# Altium schematic sheet 16_Segment_9_Digit_Display_Controller_1.SchDoc
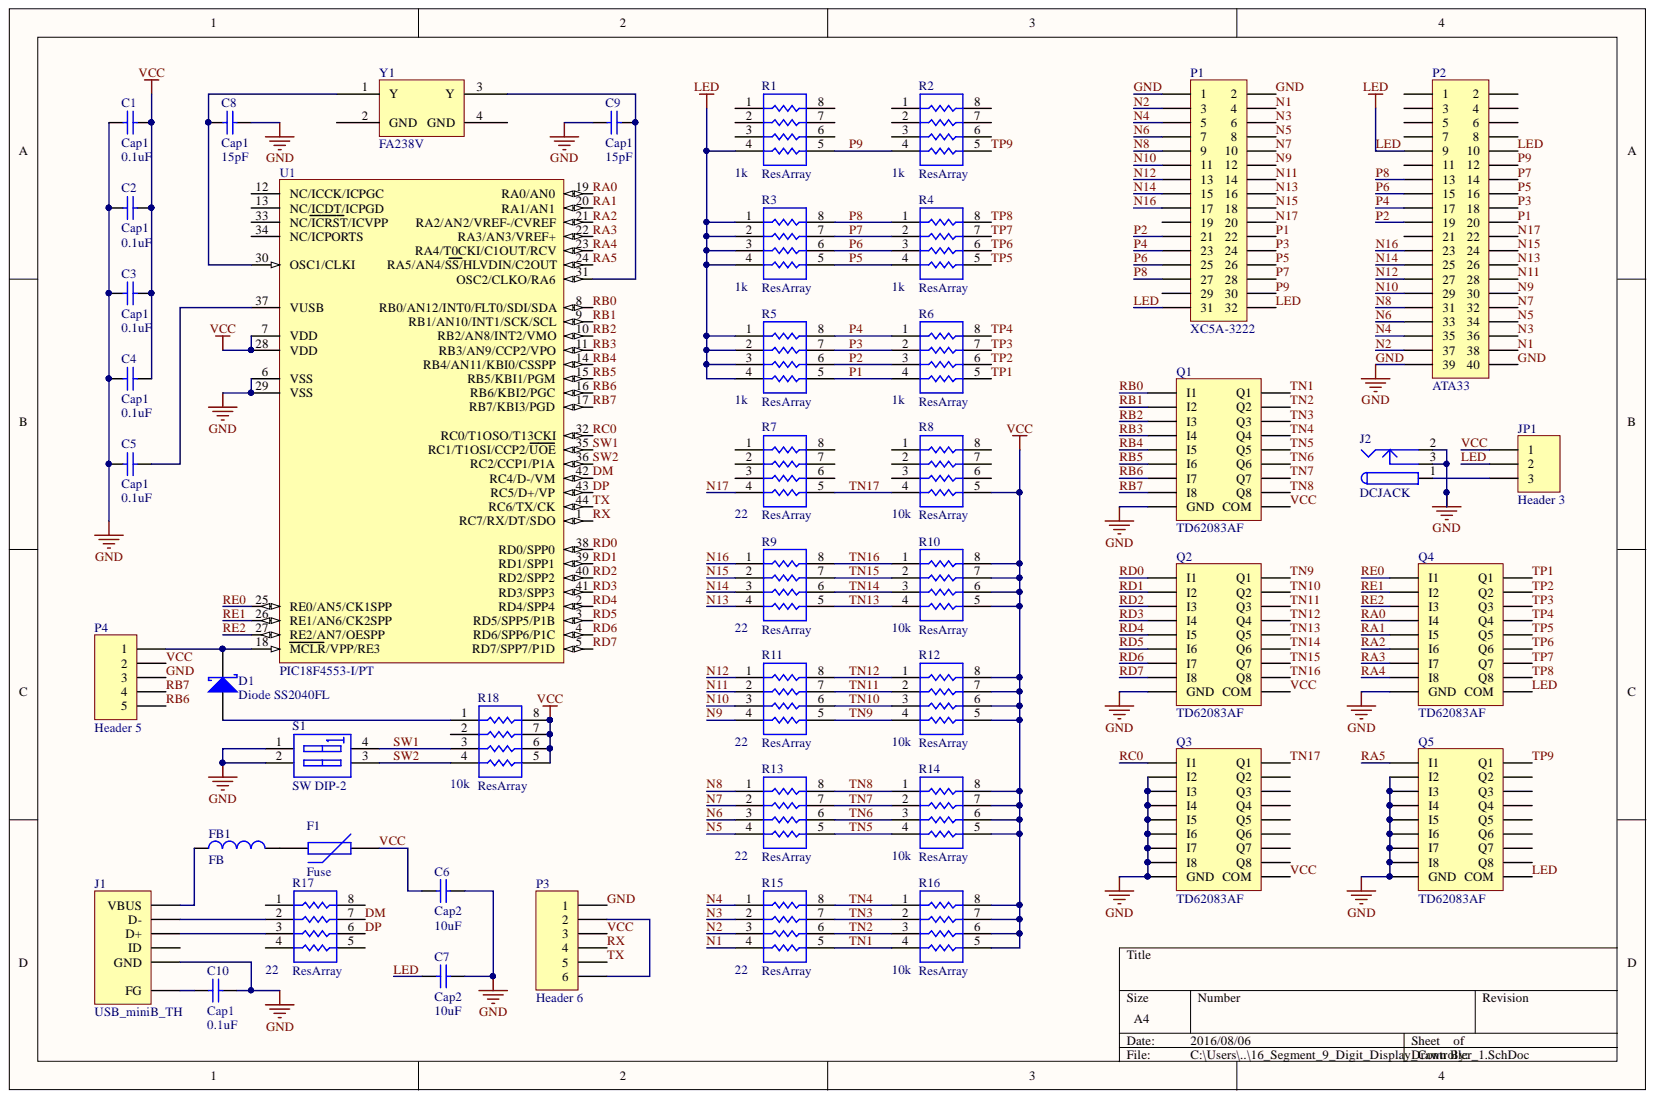
[diagram p0: 16_Segment_9_Digit_Display_Controller_1.SchDoc]

## Parts
C1  Cap1  value 0.1uF  [1608[0603]]
C2  Cap1  value 0.1uF  [1608[0603]]
C3  Cap1  value 0.1uF  [1608[0603]]
C4  Cap1  value 0.1uF  [1608[0603]]
C5  Cap1  value 0.1uF  [1608[0603]]
C6  Cap2  value 10uF  [3216]
C7  Cap2  value 10uF  [3216]
C8  Cap1  value 15pF  [1608[0603]]
C9  Cap1  value 15pF  [1608[0603]]
C10  Cap1  value 0.1uF  [1608[0603]]
D1  Diode SS2040FL  [DIODE_SMC]
F1  Fuse  [FUSE]
FB1  FB  [FB]
J1  USB_miniB_TH  [USB_miniB_TH]
J2  DCJACK  [DCJACK]
JP1  Header 3  [HDR1X3]
P1  XC5A-3222  [XC5A-3222]
P2  ATA33  [ATA33]
P3  Header 6  [HDR1X6]
P4  Header 5  [HDR1X5]
Q1  TD62083AF  [TD62083AF]
Q2  TD62083AF  [TD62083AF]
Q3  TD62083AF  [TD62083AF]
Q4  TD62083AF  [TD62083AF]
Q5  TD62083AF  [TD62083AF]
R1  ResArray  value 1k  [SO8_M]
R2  ResArray  value 1k  [SO8_M]
R3  ResArray  value 1k  [SO8_M]
R4  ResArray  value 1k  [SO8_M]
R5  ResArray  value 1k  [SO8_M]
R6  ResArray  value 1k  [SO8_M]
R7  ResArray  value 22  [SO8_M]
R8  ResArray  value 10k  [SO8_M]
R9  ResArray  value 22  [SO8_M]
R10  ResArray  value 10k  [SO8_M]
R11  ResArray  value 22  [SO8_M]
R12  ResArray  value 10k  [SO8_M]
R13  ResArray  value 22  [SO8_M]
R14  ResArray  value 10k  [SO8_M]
R15  ResArray  value 22  [SO8_M]
R16  ResArray  value 10k  [SO8_M]
R17  ResArray  value 22  [SO8_M]
R18  ResArray  value 10k  [SO8_M]
S1  SW DIP-2  [SOP4-SW]
U1  PIC18F4553-I/PT  [TQFP-PT44_M]
Y1  FA238V  [FA238V]

## Nets
DM: R17.7 U1.42(RC4/D-/VM)
DP: R17.6 U1.43(RC5/D+/VP)
GND: C1.1 C2.1 C3.1 C4.1 C5.1 C6.2 C7.2 C8.2 C9.1 C10.2 J1.5(GND) J2.2 J2.3 P1.1 P1.2 P2.39 P2.40 P3.1 P4.3 Q1.9(GND) Q2.9(GND) Q3.2(I2) Q3.3(I3) Q3.4(I4) Q3.5(I5) Q3.6(I6) Q3.7(I7) Q3.8(I8) Q3.9(GND) Q4.9(GND) Q5.2(I2) Q5.3(I3) Q5.4(I4) Q5.5(I5) Q5.6(I6) Q5.7(I7) Q5.8(I8) Q5.9(GND) S1.1 S1.2 U1.6(VSS) U1.29(VSS)
LED: C7.1 JP1.2 P1.31 P1.32 P2.9 P2.10 Q4.10(COM) Q5.10(COM) R1.4 R3.1 R3.2 R3.3 R3.4 R5.1 R5.2 R5.3 R5.4
N1: P1.4 P2.38 R15.4
N2: P1.3 P2.37 R15.3
N3: P1.6 P2.36 R15.2
N4: P1.5 P2.35 R15.1
N5: P1.8 P2.34 R13.4
N6: P1.7 P2.33 R13.3
N7: P1.10 P2.32 R13.2
N8: P1.9 P2.31 R13.1
N9: P1.12 P2.30 R11.4
N10: P1.11 P2.29 R11.3
N11: P1.14 P2.28 R11.2
N12: P1.13 P2.27 R11.1
N13: P1.16 P2.26 R9.4
N14: P1.15 P2.25 R9.3
N15: P1.18 P2.24 R9.2
N16: P1.17 P2.23 R9.1
N17: P1.20 P2.22 R7.4
P1: P1.22 P2.20 R5.5 R6.4
P2: P1.21 P2.19 R5.6 R6.3
P3: P1.24 P2.18 R5.7 R6.2
P4: P1.23 P2.17 R5.8 R6.1
P5: P1.26 P2.16 R3.5 R4.4
P6: P1.25 P2.15 R3.6 R4.3
P7: P1.28 P2.14 R3.7 R4.2
P8: P1.27 P2.13 R3.8 R4.1
P9: P1.30 P2.12 R1.5 R2.4
RA0: Q4.4(I4) U1.19(RA0/AN0)
RA1: Q4.5(I5) U1.20(RA1/AN1)
RA2: Q4.6(I6) U1.21(RA2/AN2/VREF-/CVREF)
RA3: Q4.7(I7) U1.22(RA3/AN3/VREF+)
RA4: Q4.8(I8) U1.23(RA4/T0CKI/C1OUT/RCV)
RA5: Q5.1(I1) U1.24(RA5/AN4/SS/HLVDIN/C2OUT)
RB0: Q1.1(I1) U1.8(RB0/AN12/INT0/FLT0/SDI/SDA)
RB1: Q1.2(I2) U1.9(RB1/AN10/INT1/SCK/SCL)
RB2: Q1.3(I3) U1.10(RB2/AN8/INT2/VMO)
RB3: Q1.4(I4) U1.11(RB3/AN9/CCP2/VPO)
RB4: Q1.5(I5) U1.14(RB4/AN11/KBI0/CSSPP)
RB5: Q1.6(I6) U1.15(RB5/KBI1/PGM)
RB6: P4.5 Q1.7(I7) U1.16(RB6/KBI2/PGC)
RB7: P4.4 Q1.8(I8) U1.17(RB7/KBI3/PGD)
RC0: Q3.1(I1) U1.32(RC0/T1OSO/T13CKI)
RD0: Q2.1(I1) U1.38(RD0/SPP0)
RD1: Q2.2(I2) U1.39(RD1/SPP1)
RD2: Q2.3(I3) U1.40(RD2/SPP2)
RD3: Q2.4(I4) U1.41(RD3/SPP3)
RD4: Q2.5(I5) U1.2(RD4/SPP4)
RD5: Q2.6(I6) U1.3(RD5/SPP5/P1B)
RD6: Q2.7(I7) U1.4(RD6/SPP6/P1C)
RD7: Q2.8(I8) U1.5(RD7/SPP7/P1D)
RE0: Q4.1(I1) U1.25(RE0/AN5/CK1SPP)
RE1: Q4.2(I2) U1.26(RE1/AN6/CK2SPP)
RE2: Q4.3(I3) U1.27(RE2/AN7/OESPP)
RX: P3.4 U1.1(RC7/RX/DT/SDO)
SW1: R18.3 S1.4 U1.35(RC1/T1OSI/CCP2/UOE)
SW2: R18.4 S1.3 U1.36(RC2/CCP1/P1A)
TN1: Q1.18 R15.5 R16.4
TN2: Q1.17(Q2) R15.6 R16.3
TN3: Q1.16(Q3) R15.7 R16.2
TN4: Q1.15(Q4) R15.8 R16.1
TN5: Q1.14(Q5) R13.5 R14.4
TN6: Q1.13(Q6) R13.6 R14.3
TN7: Q1.12(Q7) R13.7 R14.2
TN8: Q1.11(Q8) R13.8 R14.1
TN9: Q2.18(Q1) R11.5 R12.4
TN10: Q2.17 R11.6 R12.3
TN11: Q2.16(Q3) R11.7 R12.2
TN12: Q2.15(Q4) R11.8 R12.1
TN13: Q2.14(Q5) R9.5 R10.4
TN14: Q2.13(Q6) R9.6 R10.3
TN15: Q2.12(Q7) R9.7 R10.2
TN16: Q2.11(Q8) R9.8 R10.1
TN17: Q3.18(Q1) R7.5 R8.4
TP1: Q4.18(Q1) R6.5
TP2: Q4.17(Q2) R6.6
TP3: Q4.16(Q3) R6.7
TP4: Q4.15 R6.8
TP5: Q4.14(Q5) R4.5
TP6: Q4.13(Q6) R4.6
TP7: Q4.12(Q7) R4.7
TP8: Q4.11(Q8) R4.8
TP9: Q5.18(Q1) R2.5
TX: P3.5 U1.44(RC6/TX/CK)
VCC: C1.2 C2.2 C3.2 C4.2 C6.1 F1.2 JP1.1 P3.3 P4.2 Q1.10(COM) Q2.10(COM) Q3.10(COM) R8.5 R10.5 R10.6 R10.7 R10.8 R12.5 R12.6 R12.7 R12.8 R14.5 R14.6 R14.7 R14.8 R16.5 R16.6 R16.7 R16.8 R18.5 R18.6 R18.7 R18.8 U1.7(VDD) U1.28(VDD)
NetC5_2: C5.2 U1.37(VUSB)
NetC8_1: C8.1 U1.30(OSC1/CLKI) Y1.1(Y)
NetC9_2: C9.2 U1.31(OSC2/CLKO/RA6) Y1.3(Y)
NetC10_1: C10.1 J1.6(FG)
NetD1_1: D1.1 P4.1 U1.18(MCLR/VPP/RE3)
NetD1_2: D1.2 R18.1
NetF1_1: F1.1 FB1.2
NetFB1_1: FB1.1 J1.1(VBUS)
NetJ1_2: J1.2(D-) R17.2
NetJ1_3: J1.3(D+) R17.3
NetJ2_1: J2.1 JP1.3
NetP3_2: P3.2 P3.6

Not connected: J1 4(ID); P1 19 29; P2 1 2 3 4 5 6 7 8 11 21; Q3 11(Q8) 12(Q7) 13(Q6) 14(Q5) 15(Q4) 16 17(Q2); Q5 11(Q8) 12(Q7) 13(Q6) 14 15(Q4) 16(Q3) 17(Q2); R1 1 2 3 6 7 8; R2 1 2 3 6 7 8; R7 1 2 3 6 7 8; R8 1 2 3 6 7 8; R17 1 4 5 8; R18 2; U1 12(NC/ICCK/ICPGC) 13(NC/ICDT/ICPGD) 33(NC/ICRST/ICVPP) 34(NC/ICPORTS); Y1 2(GND) 4(GND)

## Library data of the parts
C1-C5 C8-C10 = Cap: Capacitor (Semiconductor SIM Model); Component Kind: Standard; LatestRevisionDate: 29-May-2009; LatestRevisionNote: IPC-7351 Footprint Added.; Library Name: 16_Segment_Display_Lib.IntLib; PackageDescription: Chip Capacitor; PackageReference: C1206; Published: 8-Jun-2000; Publisher: Altium Limited
C6 C7 = Cap2: Capacitor (Semiconductor SIM Model); Component Kind: Standard; LatestRevisionDate: 29-May-2009; LatestRevisionNote: IPC-7351 Footprint Added.; Library Name: 16_Segment_Display_Lib.IntLib; PackageDescription: Chip Capacitor; PackageReference: C1206; Published: 8-Jun-2000; Publisher: Altium Limited
D1 = Diode SS2040FL: Schottky Rectifier; Note: Terminal 2 is the TAB and is electrically connected to the cathode; Component Kind: Standard; ComponentLink1Description: Manufacturer Link; ComponentLink1URL: http://www.irf.com/; DatasheetVersion: PD-2.057B; LatestRevisionDate: 17-Jul-2002; LatestRevisionNote: Re-released for DXP Platform.; Library Name: 16_Segment_Display_Lib.IntLib; PackageDescription: TO, Thru-Hole, Vertical, Heatsink Mounted; 3 In-Line Leads (1 Absent); Pitch 2.54 mm; PackageReference: SOD-123FL; PackageVersion: Feb-1998; Published: 8-Jun-2000; Publisher: Altium Limited
FB1 = FB: Code_IEC: 1005; Code_JEITA: 0402; Component Kind: Standard; Description: FB; LatestRevisionDate: 29-May-2009; LatestRevisionNote: IPC-7351 Footprint Added.; Library Name: 16_Segment_Display_Lib.IntLib; PackageDescription: Chip Inductor; PackageReference: 0402-A; Published: 8-Jun-2000; Publisher: Altium Limited
J2 = DCJACK: Low Voltage Power Supply Connector; Component Kind: Standard; ComponentLink1Description: Manufacturer Link; ComponentLink1URL: http://domino.kycon.com/internet/Products.html; DatasheetDocument: 09-May-2002; Library Name: 16_Segment_Display_Lib.IntLib; Published: 17-Dec-2003; Publisher: Altium Limited
JP1 = Header 3: Header, 3-Pin; Component Kind: Standard; LatestRevisionDate: 17-Jul-2002; LatestRevisionNote: Re-released for DXP Platform.; Library Name: 16_Segment_Display_Lib.IntLib; Signal Integrity: Connector; Publisher: Altium Limited
P1 = XC5A-3222: Header, 16-Pin, Dual row; Component Kind: Standard; LatestRevisionDate: 17-Jul-2002; LatestRevisionNote: Re-released for DXP Platform.; Library Name: 16_Segment_Display_Lib.IntLib; Publisher: Altium Limited
P2 = ATA33: Header, 16-Pin, Dual row; Component Kind: Standard; LatestRevisionDate: 17-Jul-2002; LatestRevisionNote: Re-released for DXP Platform.; Library Name: 16_Segment_Display_Lib.IntLib; Publisher: Altium Limited
P3 = Header 6: Header, 6-Pin; Component Kind: Standard; LatestRevisionDate: 17-Jul-2002; LatestRevisionNote: Re-released for DXP Platform.; Library Name: 16_Segment_Display_Lib.IntLib; Signal Integrity: Connector; Publisher: Altium Limited
P4 = Header 5: Header, 5-Pin; Component Kind: Standard; LatestRevisionDate: 17-Jul-2002; LatestRevisionNote: Re-released for DXP Platform.; Library Name: 16_Segment_Display_Lib.IntLib; Signal Integrity: Connector; Publisher: Altium Limited
R1-R18 = ResArray: Resistor Array; Component Kind: Standard; Library Name: 16_Segment_Display_Lib.IntLib
S1 = SW DIP-2: DIP Switch, 2 Position, SPST; Component Kind: Standard; LatestRevisionDate: 17-Jul-2002; LatestRevisionNote: Re-released for DXP Platform.; Library Name: 16_Segment_Display_Lib.IntLib; PackageReference: DIP-4; Published: 24-Mar-1999; Publisher: Altium Limited
U1 = PIC18F4553-I/PT: High Performance, Enhanced Flash, USB Microcontroller with 12-Bit A/D and nanoWatt Technology, 32K Flash, 44-Pin TQFP, Industrial Temperature Range; Code_JEDEC: MS-026; Component Kind: Standard; ComponentLink1Description: Datasheet; ComponentLink1URL: http://ww1.microchip.com/downloads/en/DeviceDoc/39887c.pdf; ComponentLink2Description: Packaging Specification; ComponentLink2URL: http://www.microchip.com/stellent/groups/techpub_sg/documents/packagingspec/en012702.pdf; ComponentLink3Description: Microchip.com Home; ComponentLink3URL: http://www.microchip.com/; DatasheetVersion: revC, Oct-2009; LatestRevisionDate: 02-Dec-2009; LatestRevisionNote: Updated to datasheet revision C, Oct-2009; Library Name: 16_Segment_Display_Lib.IntLib; PackageDescription: 44-Lead Plastic Thin Quad Flatpack (PT) - 10x10x1mm Body, 2.00mm [TQFP]; PackageReference: TQFP-PT44; PackageVersion: revBB, Aug-2009; Published: 29-Jan-2009; Supplier 1: Digi-Key; Supplier 2: Farnell; Supplier Part Number 1: PIC18F4553-I/PT-ND; Supplier Part Number 2: 1617315; Publisher: Altium Limited
F1 = Fuse: Component Kind: Standard; Description: Fuse; LatestRevisionDate: 17-Jul-2002; LatestRevisionNote: Re-released for DXP Platform.; Library Name: 16_Segment_Display_Lib.IntLib; PackageDescription: Thru-Hole; 2 Leads; PackageReference: PIN-W2/E2.8; Published: 8-Jun-2000; Publisher: Altium Limited
J1 = USB_miniB_TH: Component Kind: Standard; Library Name: 16_Segment_Display_Lib.IntLib
Q1-Q5 = TD62083AF: Component Kind: Standard; Library Name: 16_Segment_Display_Lib.IntLib
Y1 = FA238V: Component Kind: Standard; Description: FA238V; Library Name: 16_Segment_Display_Lib.IntLib
